# Altium schematic sheet Lesegerät.SchDoc
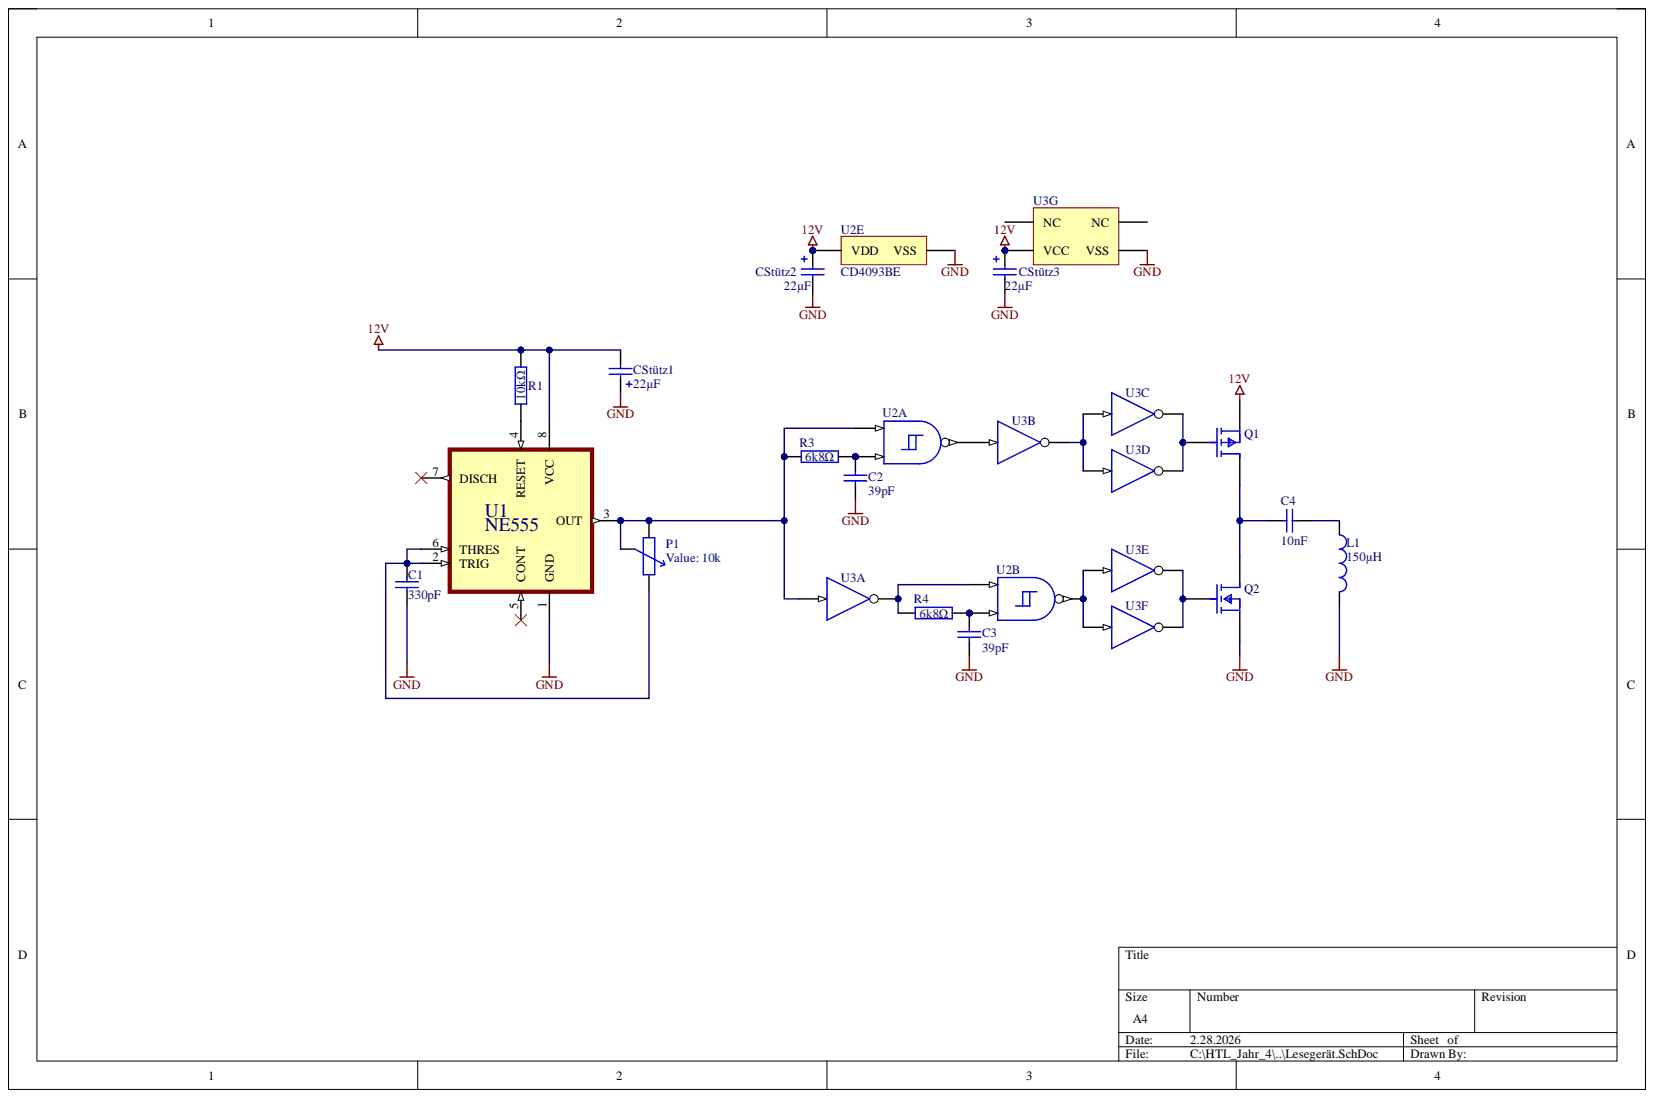
[diagram p0: Lesegerät.SchDoc]

## Parts
C1  330pF  [rad-7.2/2.5/5]
C2  value 39pF  [rad-7.2/2.5/5]  (hidden comment: KO)
C3  value 39pF  [rad-7.2/2.5/5]  (hidden comment: KO)
C4  value 10nF  [rad-7.2/2.5/5]  (hidden comment: KO)
CStütz1  value 22µF  [RB-.1/.2]  (hidden comment: ELKO)
CStütz2  value 22µF  [RB-.1/.2]  (hidden comment: ELKO)
CStütz3  value 22µF  [RB-.1/.2]  (hidden comment: ELKO)
L1  value 150µH  (hidden comment: Inductor)
P1  value 10k  [PT15]  (hidden comment: POT)
Q1  BS250  [FP-PG-SOT323-3-IPC_A]  (value not drawn)
Q2  BS170  [FP-SOT23-IPC_A]  (value not drawn)
R1  value 10kΩ  [Axial-0.4]  (hidden comment: RES)
R3  value 6k8Ω  [Axial-0.4]  (hidden comment: RES)
R4  value 6k8Ω  [Axial-0.4]  (hidden comment: RES)
U1  NE555  [DIP794W45P254L959H508Q8]
U2  CD4093BE  [N0014A]  (parts A,B,E)
U3  CD4049UBE  [N0016A]  (value not drawn)  (parts A,B,C,D,E,F,G)

## Nets
12V: CStütz1.2 CStütz2.1 CStütz3.1 Q1.2 R1.2 U1.8(VCC) U2.14(VDD) U3.1(VCC)
GND: C1.2 C2.2 C3.2 CStütz1.1 CStütz2.2 CStütz3.2 L1.2 Q2.2 U1.1(GND) U2.7(VSS) U3.8(VSS)
NetC1_1: C1.1 P1.2 U1.2(TRIG) U1.6(THRES)
NetC2_1: C2.1 R3.2 U2.2
NetC3_1: C3.1 R4.2 U2.6
NetC4_1: C4.1 Q1.3 Q2.3
NetC4_2: C4.2 L1.1
NetP1_1: P1.1 P1.3 R3.1 U1.3(OUT) U2.1 U3.3
NetQ1_G2: Q1.G2 U3.6 U3.10
NetQ2_G1: Q2.G1 U3.12 U3.15
NetR1_1: R1.1 U1.4(RESET)
NetR4_1: R4.1 U2.5 U3.2
NetU1_5: U1.5(CONT)
NetU1_7: U1.7(DISCH)
NetU2_3: U2.3 U3.5
NetU2_4: U2.4 U3.11 U3.14
NetU3_4: U3.4 U3.7 U3.9
NetU3_13: U3.13(NC)
NetU3_16: U3.16(NC)

## Library data of the parts
C1-C4 = KO: Kondensator; Component Kind: Standard; Library Name: HTL-sym-2021_04.schlib; Simulation: Model Name
L1 = Inductor: SimulationGenericComponent: True; Component Kind: Standard; Description: Inductor; Library Name: Simulation Generic Components.IntLib; Simulation: L_Inductor_Default
P1 = POT: Potentiometer; Set Position: 0.5; Component Kind: Standard; Library Name: HTL-sym-2021_04.schlib; Simulation: Model Name
Q1 = CMP-32901-000121-1: MOSFET P-CH 60V 150MA SOT-323; Manufacturer: Infineon; Manufacturer Part Number: BSS84PWH6327XTSA1; Automotive Grade: Grade 0; Continuous Drain Current: -0.15A; Depletion Mode: False; Drain To Source Breakdown Voltage: -60V; Drain To Source Resistance: 6.9Ω; Gate Charge At Vgs: 1nC @ -10V; Gate To Source Voltage: 20V; Input Capacitace At Vds: 15.3pF @ -25V; Max Forward Diode Voltage: -1.12V; Max Junction Temp: +150°C; Number Of Channels: 1; Power Dissipation: 0.3W; Pulse Drain Current: -0.6A; Reverse Recovery Charge: 11.6nC; Reverse Recovery Time: 23.6ns; Rthja Max: 420K/W; Threshold Vgs Max: -2V; Threshold Vgs Min: -1V; Transconductance: 0.16S; Turn Off Delay Time: 8.6ns; Turn On Delay Time: 6.7ns; Typ Forward Diode Voltage: -0.84V; Automotive: Yes; Category: Trans; Component Kind: Standard; ComponentLink2Description: Datasheet; ComponentLink2URL: https://www.infineon.com/dgdl/Infineon-BSS84PW-DS-v02_00-EN.pdf?fileId=db3a3043321e49940132482056d32474; Device Class L1: Discrete Semiconductors; Device Class L2: Transistors; Device Class L3: MOSFETs; Digikey Description: MOSFET P-CH 60V 150MA SOT-323; Drain To Source Voltage: -60V; Footprint Url: https://www.infineon.com/cms/en/product/packages/PG-SOT323/PG-SOT323-3-2/; Height: 1mm; Ipc Land Pattern Name: SOT65P210X90-3; Lead Free: Yes; Library Name: Components - 01.04.25 - 10.34.SchLib; Mouser Description: MOSFET P-Ch -60V 150mA SOT-323-3; Number Of P Channels: 1; Octopart: https://octopart.com/bss84pwh6327xtsa1-infineon-22361355; Package: PG-SOT323-3; RoHS: TRUE; Temperature: -55°C to +150°C; Temperature Range High: +150°C; Temperature Range Low: -55°C
Q2 = CMP-12801-000064-2: MOSFET N-CH 60V .15MA SOT23-3; Continuous Drain Current (ID): 150mA; Drain to Source Resistance: 5R; Element Configuration: Single; Gate to Source Voltage (Vgs): 20V; Input Capacitance: 60pF; Max Power Dissipation: 330mW; Nominal Vgs: 3V; Number of Channels: 1; Turn-Off Delay Time: 10ns; Case/Package: SOT-23; Component Kind: Standard; Contact Plating: Tin; Current Rating: 150mA; Drain to Source Breakdown Voltage: 60V; Drain to Source Voltage (Vdss): 60V; Dual Supply Voltage: 60V; Height: 1mm; Lead Free: Lead Free; Length: 3.05mm; Library Name: Components - 01.04.25 - 10.32.SchLib; Max Operating Temperature: 150°C; Min Operating Temperature: -55°C; Mount: Surface Mount; Number of Elements: 1; Number of Pins: 3; On-State Resistance: 5R; Package Quantity: 3000; Packaging: Tape and Reel; Power Dissipation: 330mW; Rds On Max: 5R; REACH SVHC: No SVHC; Termination: SMD/SMT; Threshold Voltage: 3V; Turn-On Delay Time: 10ns; Voltage Rating (DC): 60V; Weight: 0.000282oz; Width: 1.4mm
R1 R3 R4 = RES: Widerstand; Component Kind: Standard; Library Name: HTL-sym-2021_04.schlib; Simulation: Model Name
U1 = NE555P: Single Precision Timer; MF: Texas; Check_prices: https://www.snapeda.com/parts/NE555P/Texas+Instruments/view-part/?ref=eda; Component Kind: Standard; Library Name: NE.SCHLIB; MP: NE555P; Package: PDIP-8 Texas; SnapEDA_Link: https://www.snapeda.com/parts/NE555P/Texas+Instruments/view-part/?ref=snap
U2 = CMP-1627-00493-3: CMOS Quad 2-Input NAND Schmitt Triggers, N0014A, TUBE; Manufacturer: Texas Instruments; Bits(#): 4; F @ Nom Voltage(Max)(Mhz): 8; ICC @ Nom Voltage(Max)(mA): 0.06; Output Drive (IOL/IOH)(Max)(mA): 1.5/-1.5; Rating: Catalog; Schmitt Trigger: Yes; Technology Family: CD4000; VCC(Max)(V): 18; VCC(Min)(V): 3; Voltage(Nom)(V): 10; Code_JEDEC: MS-001; Component Kind: Standard; DatasheetVersion: SCHS115D; Generic Part Number: CD4093B; Input Type: CMOS; Library Name: Components - 01.04.25 - 10.05.SchLib; Operating Temperature Range(C): -55 to 125; Output Type: CMOS; PackageReference: N0014A
U3 = CMP-1615-00914-2: CMOS Hex Inverting Buffer/Converter, N0016A, TUBE; Manufacturer: Texas Instruments; Bits(#): 6; F @ Nom Voltage(Max)(Mhz): 12; ICC @ Nom Voltage(Max)(mA): 0.03; Output Drive (IOL/IOH)(Max)(mA): -1.2/5.2; Rating: Military; Schmitt Trigger: No; Technology Family: CD4000; tpd @ Nom Voltage(Max)(ns): 120; VCC(Max)(V): 18; VCC(Min)(V): 3; Voltage(Nom)(V): 5; Code_JEDEC: MS-001; Component Kind: Standard; DatasheetVersion: SCHS046I; Generic Part Number: CD4049UB; Input Type: CMOS; Library Name: Components - 01.04.25 - 10.16.SchLib; Operating Temperature Range(C): -55 to 125; Output Type: CMOS; PackageReference: N0016A
CStütz1-CStütz3 = ELKO: Component Kind: Standard; Description: Elko; Library Name: HTL-sym-2021_04.schlib; Simulation: Model Name
